# Altium schematic sheet controller_slave_3.SchDoc(controller_slave_3)
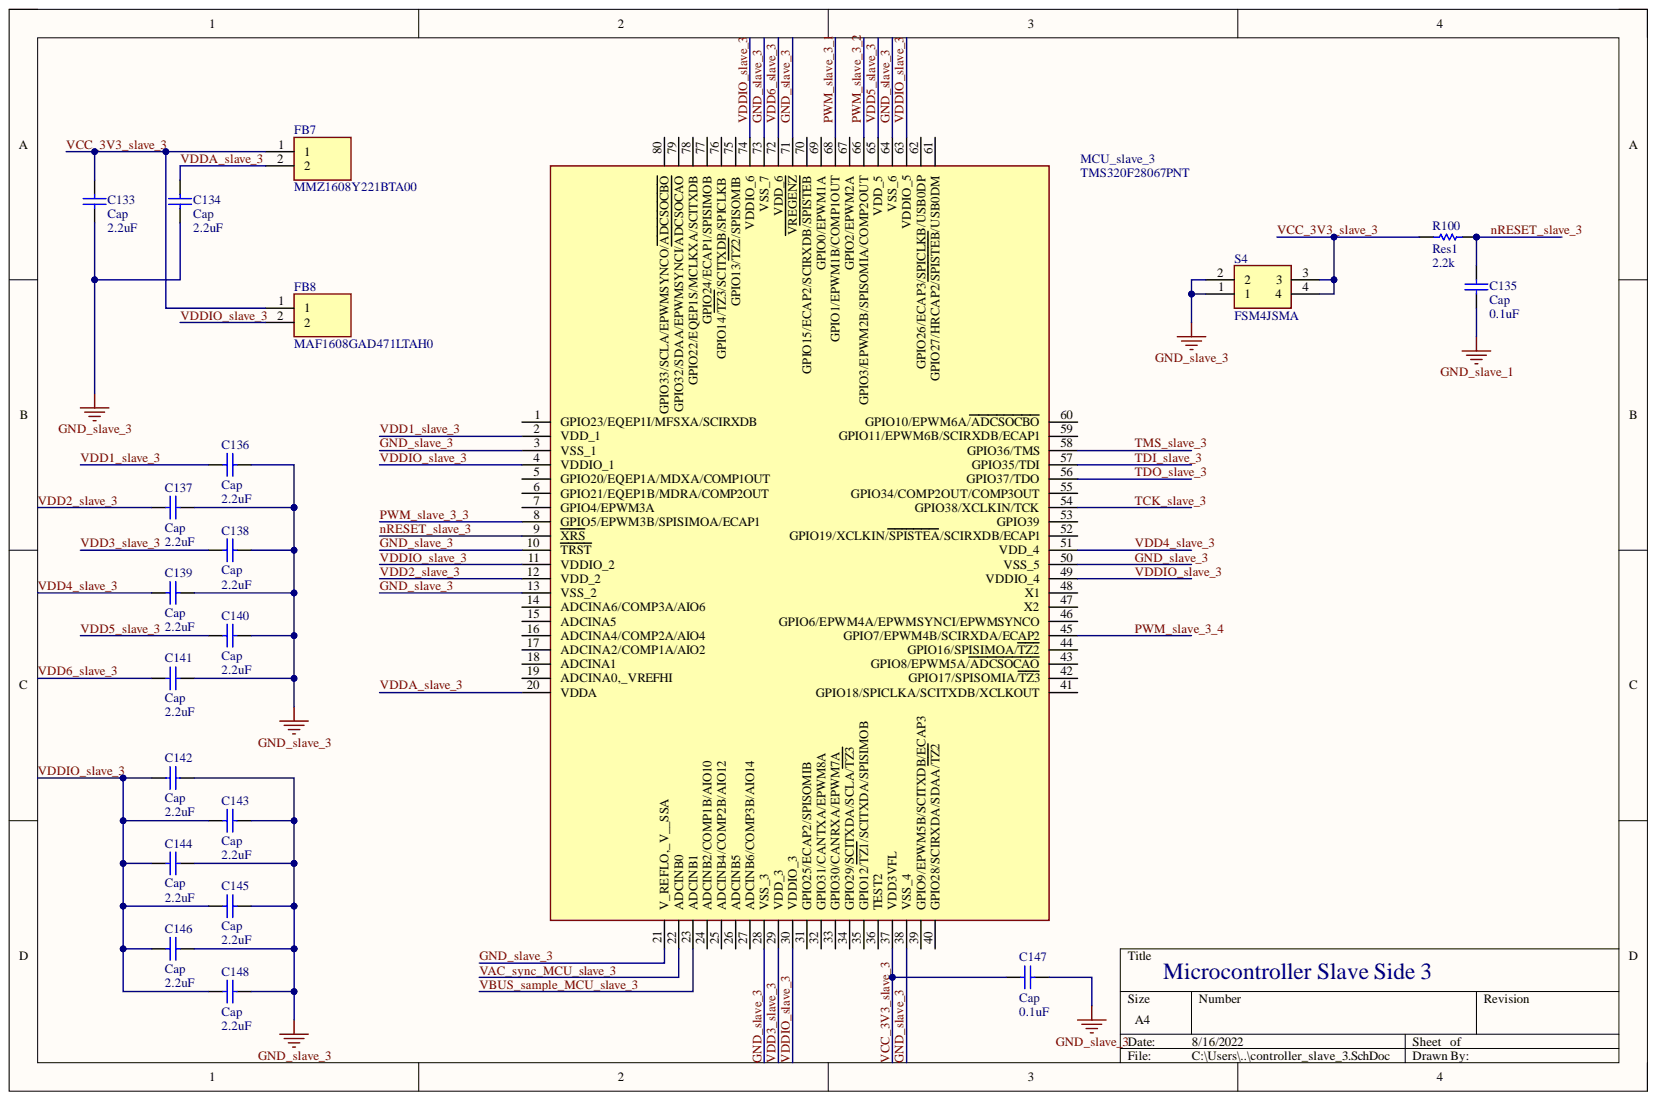
[diagram p0: controller_slave_3.SchDoc]

## Parts
C133  Cap  value 2.2uF  [C0805]
C134  Cap  value 2.2uF  [C0805]
C135  Cap  value 0.1uF  [C0805]
C136  Cap  value 2.2uF  [C0805]
C137  Cap  [C0805]
C138  Cap  value 2.2uF  [C0805]
C139  Cap  value 2.2uF  [C0805]
C140  Cap  value 2.2uF  [C0805]
C141  Cap  value 2.2uF  [C0805]
C142  Cap  value 2.2uF  [C0805]
C143  Cap  value 2.2uF  [C0805]
C144  Cap  value 2.2uF  [C0805]
C145  Cap  value 2.2uF  [C0805]
C146  Cap  value 2.2uF  [C0805]
C147  Cap  value 0.1uF  [C0805]
C148  Cap  value 2.2uF  [C0805]
FB7  MMZ1608Y221BTA00  [BEADC1608X95N]
FB8  MAF1608GAD471LTAH0  [BEADC1608X75N]
MCU_slave_3  TMS320F28067PNT  [QFP50P1400X1400X160-80N]
R100  Res1  value 2.2k  [RES0805W]
S4  FSM4JSMA  [FSM4JSMA]

## Nets
GND_slave_1: C135.2
GND_slave_3: C133.2 C134.2 C136.1 C137.1 C138.1 C139.1 C140.1 C141.1 C142.1 C143.1 C144.1 C145.1 C146.1 C147.1 C148.1 MCU_slave_3.3(VSS_1) MCU_slave_3.10(TRST) MCU_slave_3.13(VSS_2) MCU_slave_3.21(V_REFLO,_V__SSA) MCU_slave_3.28(VSS_3) MCU_slave_3.38(VSS_4) MCU_slave_3.50(VSS_5) MCU_slave_3.64(VSS_6) MCU_slave_3.71(VREGENZ) MCU_slave_3.73(VSS_7) S4.1 S4.2
nRESET_slave_3: C135.1 MCU_slave_3.9(XRS) R100.2
PWM_slave_3_1: MCU_slave_3.68(GPIO1/EPWM1B/COMP1OUT)
PWM_slave_3_2: MCU_slave_3.66(GPIO3/EPWM2B/SPISOMIA/COMP2OUT)
PWM_slave_3_3: MCU_slave_3.8(GPIO5/EPWM3B/SPISIMOA/ECAP1)
PWM_slave_3_4: MCU_slave_3.45(GPIO7/EPWM4B/SCIRXDA/ECAP2)
TCK_slave_3: MCU_slave_3.54(GPIO38/XCLKIN/TCK)
TDI_slave_3: MCU_slave_3.57(GPIO35/TDI)
TDO_slave_3: MCU_slave_3.56(GPIO37/TDO)
TMS_slave_3: MCU_slave_3.58(GPIO36/TMS)
VAC_sync_MCU_slave_3: MCU_slave_3.22(ADCINB0)
VBUS_sample_MCU_slave_3: MCU_slave_3.23(ADCINB1)
VCC_3V3_slave_3: C133.1 C147.2 FB7.1 FB8.1 MCU_slave_3.37(VDD3VFL) R100.1 S4.3 S4.4
VDD1_slave_3: C136.2 MCU_slave_3.2(VDD_1)
VDD2_slave_3: C137.2 MCU_slave_3.12(VDD_2)
VDD3_slave_3: C138.2 MCU_slave_3.29(VDD_3)
VDD4_slave_3: C139.2 MCU_slave_3.51(VDD_4)
VDD5_slave_3: C140.2 MCU_slave_3.65(VDD_5)
VDD6_slave_3: C141.2 MCU_slave_3.72(VDD_6)
VDDA_slave_3: C134.1 FB7.2 MCU_slave_3.20(VDDA)
VDDIO_slave_3: C142.2 C143.2 C144.2 C145.2 C146.2 C148.2 FB8.2 MCU_slave_3.4(VDDIO_1) MCU_slave_3.11(VDDIO_2) MCU_slave_3.30(VDDIO_3) MCU_slave_3.49(VDDIO_4) MCU_slave_3.63(VDDIO_5) MCU_slave_3.74(VDDIO_6)

Not connected: MCU_slave_3 1(GPIO23/EQEP1I/MFSXA/SCIRXDB) 5(GPIO20/EQEP1A/MDXA/COMP1OUT) 6(GPIO21/EQEP1B/MDRA/COMP2OUT) 7(GPIO4/EPWM3A) 14(ADCINA6/COMP3A/AIO6) 15(ADCINA5) 16(ADCINA4/COMP2A/AIO4) 17(ADCINA2/COMP1A/AIO2) 18(ADCINA1) 19(ADCINA0,_VREFHI) 24(ADCINB2/COMP1B/AIO10) 25(ADCINB4/COMP2B/AIO12) 26(ADCINB5) 27(ADCINB6/COMP3B/AIO14) 31(GPIO25/ECAP2/SPISOMIB) 32(GPIO31/CANTXA/EPWM8A) 33(GPIO30/CANRXA/EPWM7A) 34(GPIO29/SCITXDA/SCLA/TZ3) 35(GPIO12/TZ1/SCITXDA/SPISIMOB) 36(TEST2) 39(GPIO9/EPWM5B/SCITXDB/ECAP3) 40(GPIO28/SCIRXDA/SDAA/TZ2) 41(GPIO18/SPICLKA/SCITXDB/XCLKOUT) 42(GPIO17/SPISOMIA/TZ3) 43(GPIO8/EPWM5A/ADCSOCAO) 44(GPIO16/SPISIMOA/TZ2) 46(GPIO6/EPWM4A/EPWMSYNCI/EPWMSYNCO) 47(X2) 48(X1) 52(GPIO19/XCLKIN/SPISTEA/SCIRXDB/ECAP1) 53(GPIO39) 55(GPIO34/COMP2OUT/COMP3OUT) 59(GPIO11/EPWM6B/SCIRXDB/ECAP1) 60(GPIO10/EPWM6A/ADCSOCBO) 61(GPIO27/HRCAP2/SPISTEB/USB0DM) 62(GPIO26/ECAP3/SPICLKB/USB0DP) 67(GPIO2/EPWM2A) 69(GPIO0/EPWM1A) 70(GPIO15/ECAP2/SCIRXDB/SPISTEB) 75(GPIO13/TZ2/SPISOMIB) 76(GPIO14/TZ3/SCITXDB/SPICLKB) 77(GPIO24/ECAP1/SPISIMOB) 78(GPIO22/EQEP1S/MCLKXA/SCITXDB) 79(GPIO32/SDAA/EPWMSYNCI/ADCSOCAO) 80(GPIO33/SCLA/EPWMSYNCO/ADCSOCBO)

## Library data of the parts
C133-C136 C138 C140 C141 C143 C145 C147 C148 = Cap: Capacitor; Component Kind: Standard; LatestRevisionDate: 17-Jul-2002; LatestRevisionNote: Re-released for DXP Platform.; Library Name: Miscellaneous Devices.IntLib; PackageDescription: Radial Cap, Thru-Hole; 2 Leads; 0.3 in Pin Spacing; PackageReference: RAD-0.3; Published: 8-Jun-2000; Signal Integrity: Cap; Simulation: CAP; Publisher: Altium Limited
C137 C139 C142 C144 C146 = Cap: Capacitor; Value: 2.2uF; Component Kind: Standard; LatestRevisionDate: 17-Jul-2002; LatestRevisionNote: Re-released for DXP Platform.; Library Name: Miscellaneous Devices.IntLib; PackageDescription: Radial Cap, Thru-Hole; 2 Leads; 0.3 in Pin Spacing; PackageReference: RAD-0.3; Published: 8-Jun-2000; Signal Integrity: Cap; Simulation: CAP; Publisher: Altium Limited
FB7 = MMZ1608Y221BTA00: Ferrite Bead; Manufacturer_Name: TDK; Component Kind: Standard; Datasheet Link: https://product.tdk.com/system/files/dam/doc/product/emc/emc/beads/catalog/beads_commercial_signal_mmz1608_en.pdf; Height: 0.95mm; Manufacturer_Part_Number: MMZ1608Y221BTA00
FB8 = MAF1608GAD471LTAH0: Filter; Manufacturer_Name: TDK; Component Kind: Standard; Datasheet Link: https://componentsearchengine.com//MAF1608GAD471LTAH0.pdf; Height: 0.75mm; Manufacturer_Part_Number: MAF1608GAD471LTAH0; Mouser2 Part Number: 810-MAF1608GAD471LTA; Mouser2 Price/Stock: https://www.mouser.com/Search/Refine.aspx?Keyword=810-MAF1608GAD471LTA
MCU_slave_3 = TMS320F28067PNT: Integrated Circuit; Manufacturer_Name: Texas Instruments; Component Kind: Standard; Datasheet Link: http://www.ti.com/lit/gpn/TMS320F28067; Height: 1.6mm; Manufacturer_Part_Number: TMS320F28067PNT
R100 = Res1: Resistor; Value: 2.2k; Component Kind: Standard; LatestRevisionDate: 17-Jul-2002; LatestRevisionNote: Re-released for DXP Platform.; Library Name: Miscellaneous Devices.IntLib; PackageDescription: Axial Device, Thru-Hole; 2 Leads; 0.3 in Pin Spacing; PackageReference: AXIAL-0.3; Published: 8-Jun-2000; Signal Integrity: Res; Simulation: RESISTOR; Publisher: Altium Limited
S4 = FSM4JSMA: Switch; Manufacturer_Name: TE Connectivity; Component Kind: Standard; Datasheet Link: https://componentsearchengine.com//FSM4JSMA.pdf; Height: 5.25mm; Library Name: FSM4JSMA.SchLib; Manufacturer_Part_Number: FSM4JSMA; Mouser2 Part Number: 506-FSM4JSMA; Mouser2 Price/Stock: https://www.mouser.com/Search/Refine.aspx?Keyword=506-FSM4JSMA
